# Altium schematic sheet Controle.SchDoc
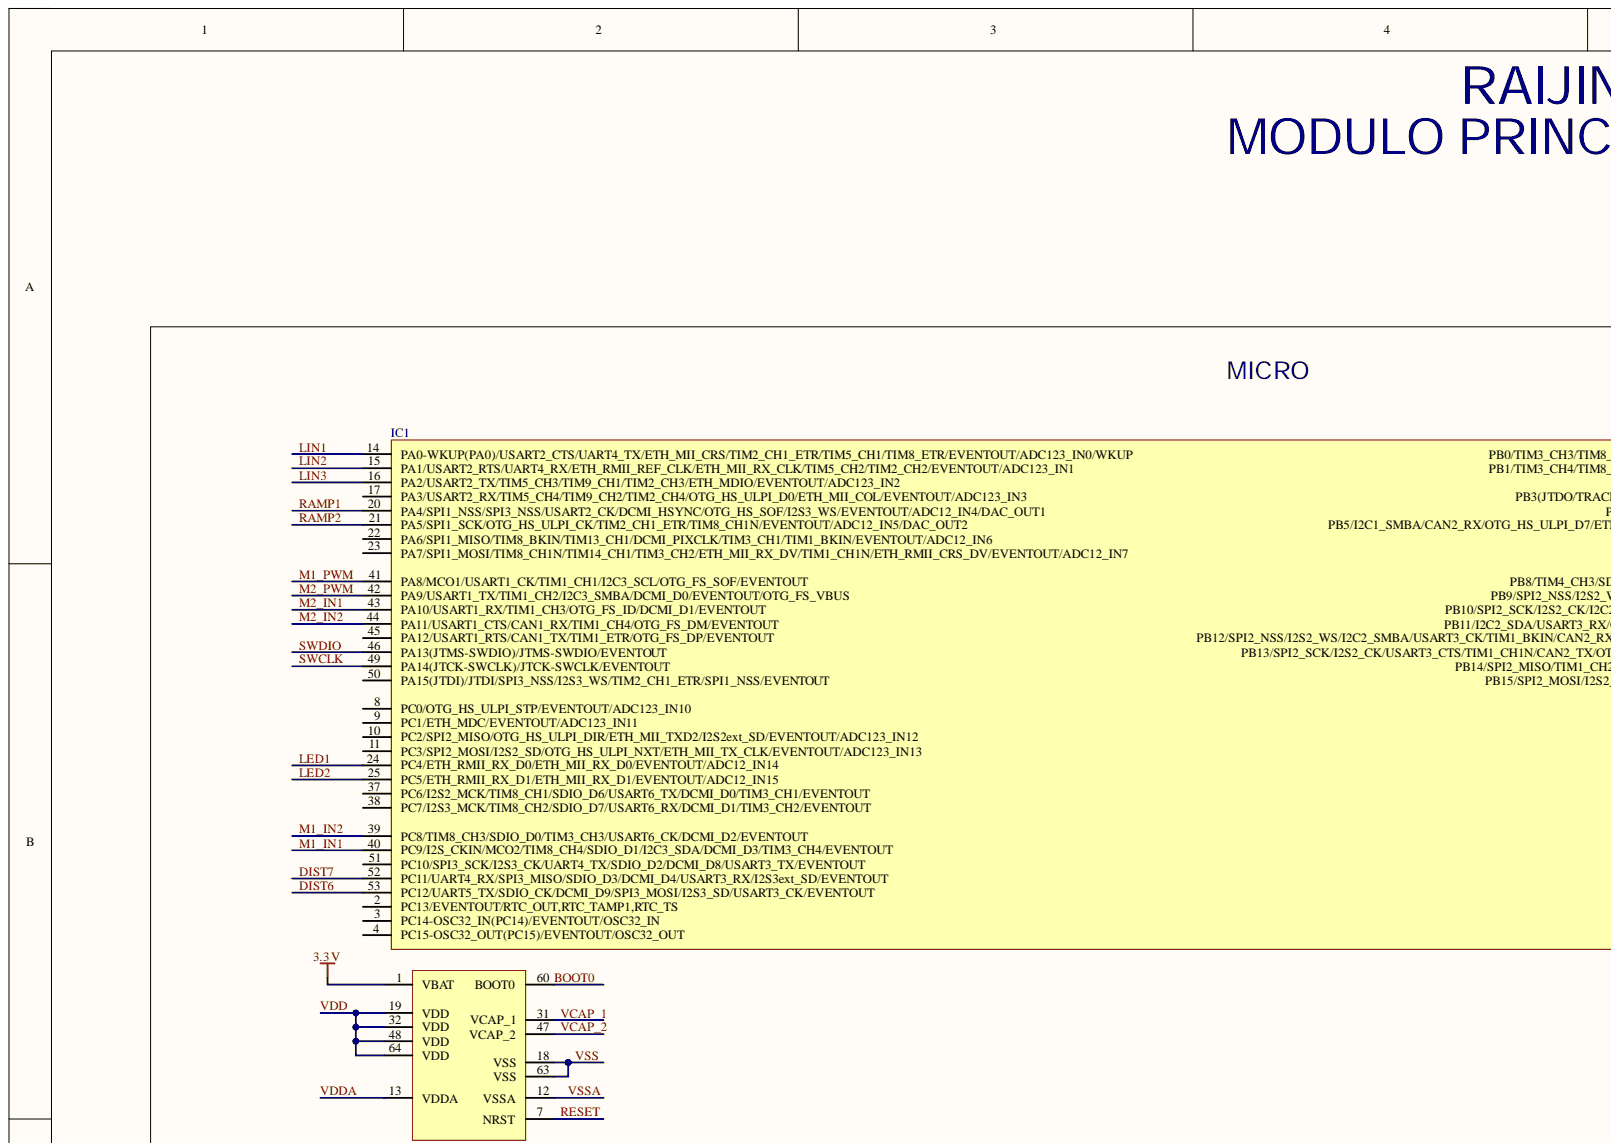
[diagram p0: Controle.SchDoc, top left]
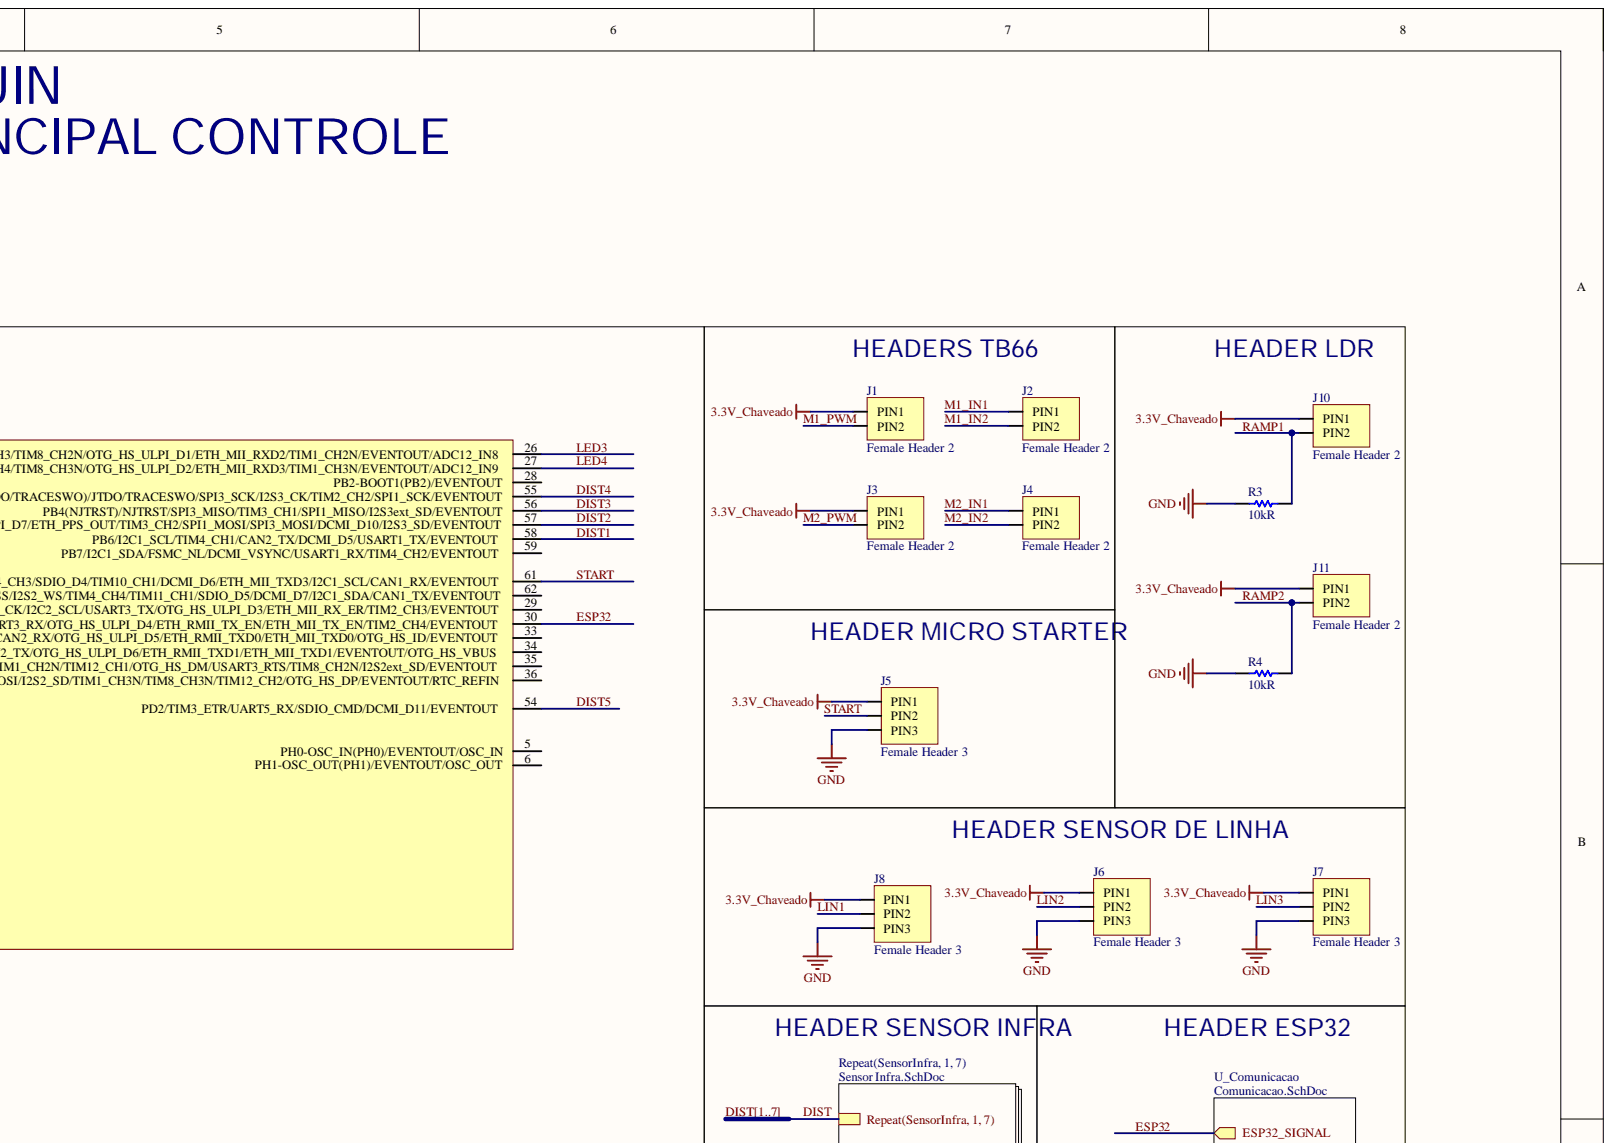
[diagram p1: Controle.SchDoc, top right]
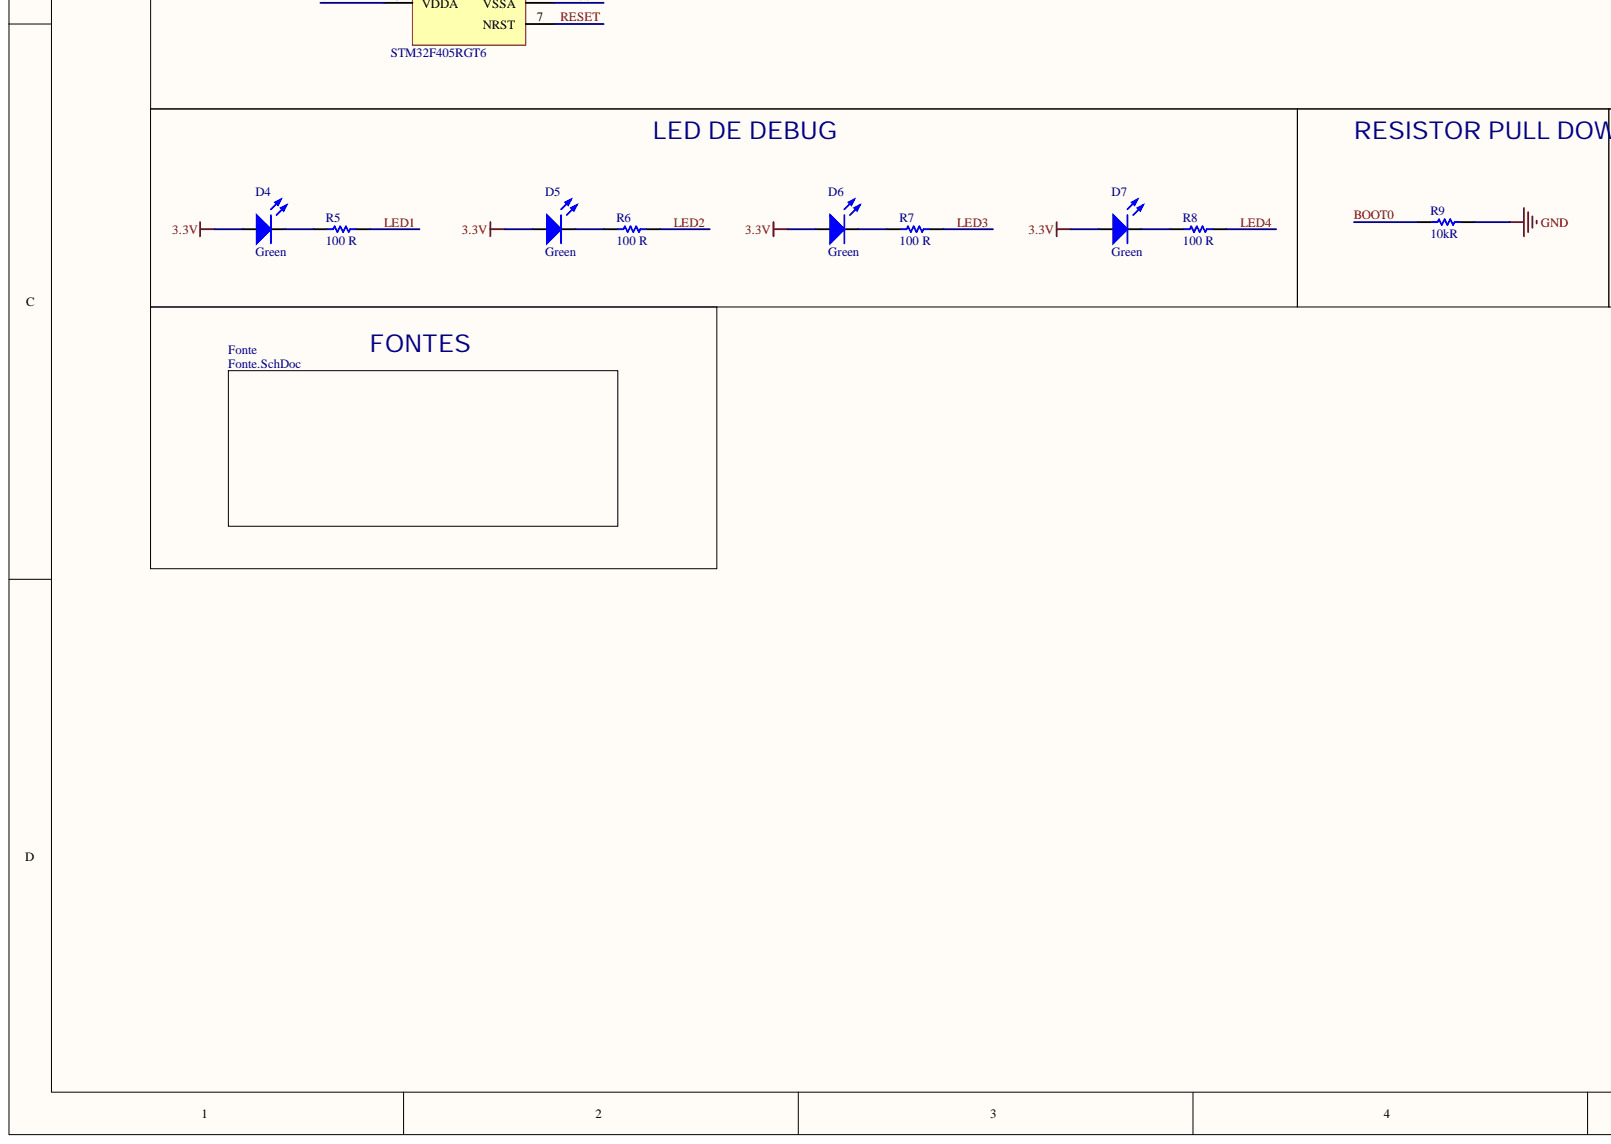
[diagram p2: Controle.SchDoc, bottom left]
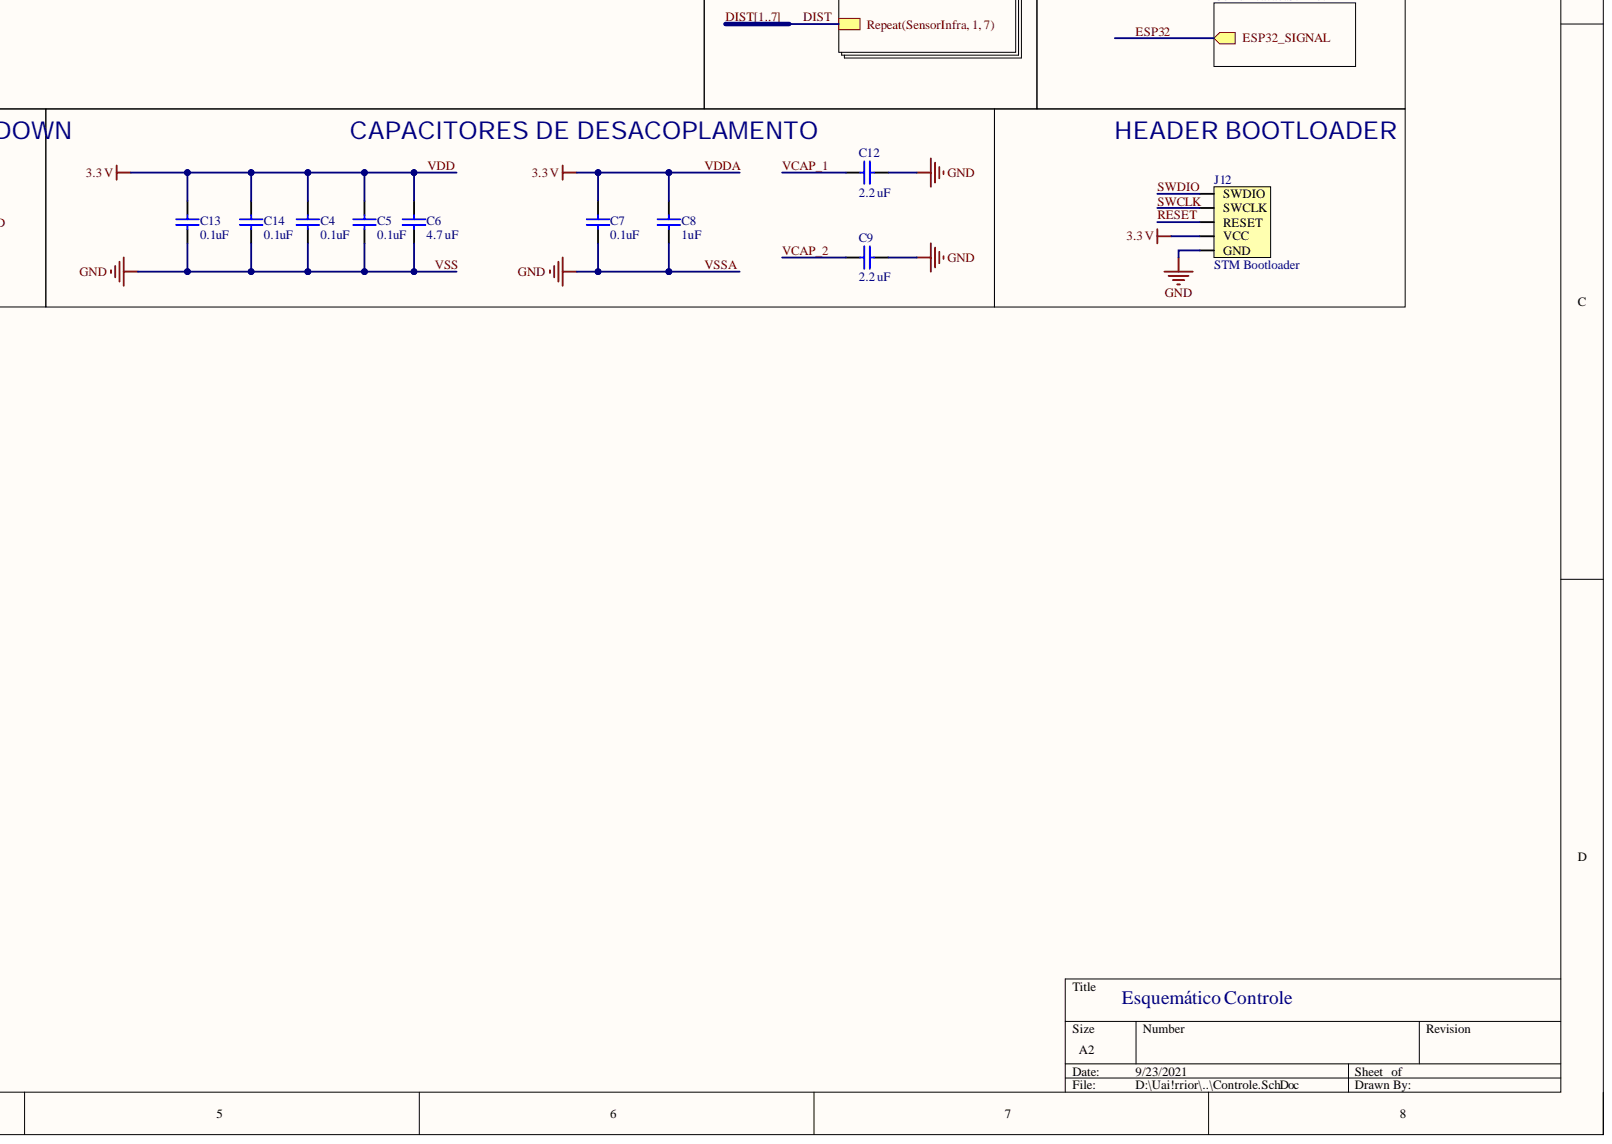
[diagram p3: Controle.SchDoc, bottom right]

## Parts
C4  0.1uF  [CAPC1005X55N]
C5  0.1uF  [CAPC1005X55N]
C6  4.7 uF  [C0603]
C7  0.1uF  [CAPC1005X55N]
C8  1uF  [C0805]
C9  2.2 uF  [C0402]
C12  2.2 uF  [C0402]
C13  0.1uF  [CAPC1005X55N]
C14  0.1uF  [CAPC1005X55N]
D4  Green  [LED_0603]
D5  Green  [LED_0603]
D6  Green  [LED_0603]
D7  Green  [LED_0603]
IC1  STM32F405RGT6  [LQFP-64]
J1  Female Header 2  [Female Header 2]
J2  Female Header 2  [Female Header 2]
J3  Female Header 2  [Female Header 2]
J4  Female Header 2  [Female Header 2]
J5  Female Header 3  Library Ref: Header  [FEMALE HEADER 3]
J6  Female Header 3  Library Ref: Header  [FEMALE HEADER 3]
J7  Female Header 3  Library Ref: Header  [FEMALE HEADER 3]
J8  Female Header 3  Library Ref: Header  [FEMALE HEADER 3]
J10  Female Header 2  [Female Header 2]
J11  Female Header 2  [Female Header 2]
J12  STM Bootloader  [STM BOOTLOADER]
R3  10kR  [RESISTOR_0402]
R4  10kR  [RESISTOR_0402]
R5  100 R  [RESISTOR_0603]
R6  100 R  [RESISTOR_0603]
R7  100 R  [RESISTOR_0603]
R8  100 R  [RESISTOR_0603]
R9  10kR  [RESISTOR_0402]

## Nets
3.3V: D4.A D5.A D6.A D7.A
3.3V_Chaveado: J1.PIN1 J3.PIN1 J5.PIN1 J6.PIN1 J7.PIN1 J8.PIN1 J10.PIN1 J11.PIN1
BOOT0: IC1.60(BOOT0) R9.1
DIST1: IC1.58(PB6/I2C1_SCL/TIM4_CH1/CAN2_TX/DCMI_D5/USART1_TX/EVENTOUT)  [also labelled DIST]
DIST2: IC1.57(PB5/I2C1_SMBA/CAN2_RX/OTG_HS_ULPI_D7/ETH_PPS_OUT/TIM3_CH2/SPI1_MOSI/SPI3_MOSI/DCMI_D10/I2S3_SD/EVENTOUT)  [also labelled DIST]
DIST3: IC1.56(PB4(NJTRST)/NJTRST/SPI3_MISO/TIM3_CH1/SPI1_MISO/I2S3ext_SD/EVENTOUT)  [also labelled DIST]
DIST4: IC1.55(PB3(JTDO/TRACESWO)/JTDO/TRACESWO/SPI3_SCK/I2S3_CK/TIM2_CH2/SPI1_SCK/EVENTOUT)  [also labelled DIST]
DIST5: IC1.54(PD2/TIM3_ETR/UART5_RX/SDIO_CMD/DCMI_D11/EVENTOUT)  [also labelled DIST]
DIST6: IC1.53(PC12/UART5_TX/SDIO_CK/DCMI_D9/SPI3_MOSI/I2S3_SD/USART3_CK/EVENTOUT)  [also labelled DIST]
DIST7: IC1.52(PC11/UART4_RX/SPI3_MISO/SDIO_D3/DCMI_D4/USART3_RX/I2S3ext_SD/EVENTOUT)  [also labelled DIST]
ESP32: IC1.30(PB11/I2C2_SDA/USART3_RX/OTG_HS_ULPI_D4/ETH_RMII_TX_EN/ETH_MII_TX_EN/TIM2_CH4/EVENTOUT)
LED1: IC1.24(PC4/ETH_RMII_RX_D0/ETH_MII_RX_D0/EVENTOUT/ADC12_IN14) R5.2
LED2: IC1.25(PC5/ETH_RMII_RX_D1/ETH_MII_RX_D1/EVENTOUT/ADC12_IN15) R6.2
LED3: IC1.26(PB0/TIM3_CH3/TIM8_CH2N/OTG_HS_ULPI_D1/ETH_MII_RXD2/TIM1_CH2N/EVENTOUT/ADC12_IN8) R7.2
LED4: IC1.27(PB1/TIM3_CH4/TIM8_CH3N/OTG_HS_ULPI_D2/ETH_MII_RXD3/TIM1_CH3N/EVENTOUT/ADC12_IN9) R8.2
LIN1: IC1.14(PA0-WKUP(PA0)/USART2_CTS/UART4_TX/ETH_MII_CRS/TIM2_CH1_ETR/TIM5_CH1/TIM8_ETR/EVENTOUT/ADC123_IN0/WKUP) J8.PIN2
LIN2: IC1.15(PA1/USART2_RTS/UART4_RX/ETH_RMII_REF_CLK/ETH_MII_RX_CLK/TIM5_CH2/TIM2_CH2/EVENTOUT/ADC123_IN1) J6.PIN2
LIN3: IC1.16(PA2/USART2_TX/TIM5_CH3/TIM9_CH1/TIM2_CH3/ETH_MDIO/EVENTOUT/ADC123_IN2) J7.PIN2
M1_IN1: IC1.40(PC9/I2S_CKIN/MCO2/TIM8_CH4/SDIO_D1/I2C3_SDA/DCMI_D3/TIM3_CH4/EVENTOUT) J2.PIN1
M1_IN2: IC1.39(PC8/TIM8_CH3/SDIO_D0/TIM3_CH3/USART6_CK/DCMI_D2/EVENTOUT) J2.PIN2
M1_PWM: IC1.41(PA8/MCO1/USART1_CK/TIM1_CH1/I2C3_SCL/OTG_FS_SOF/EVENTOUT) J1.PIN2
M2_IN1: IC1.43(PA10/USART1_RX/TIM1_CH3/OTG_FS_ID/DCMI_D1/EVENTOUT) J4.PIN1
M2_IN2: IC1.44(PA11/USART1_CTS/CAN1_RX/TIM1_CH4/OTG_FS_DM/EVENTOUT) J4.PIN2
M2_PWM: IC1.42(PA9/USART1_TX/TIM1_CH2/I2C3_SMBA/DCMI_D0/EVENTOUT/OTG_FS_VBUS) J3.PIN2
RAMP1: IC1.20(PA4/SPI1_NSS/SPI3_NSS/USART2_CK/DCMI_HSYNC/OTG_HS_SOF/I2S3_WS/EVENTOUT/ADC12_IN4/DAC_OUT1) J10.PIN2 R3.2
RAMP2: IC1.21(PA5/SPI1_SCK/OTG_HS_ULPI_CK/TIM2_CH1_ETR/TIM8_CH1N/EVENTOUT/ADC12_IN5/DAC_OUT2) J11.PIN2 R4.2
RESET: IC1.7 J12.RESET
START: IC1.61(PB8/TIM4_CH3/SDIO_D4/TIM10_CH1/DCMI_D6/ETH_MII_TXD3/I2C1_SCL/CAN1_RX/EVENTOUT) J5.PIN2
SWCLK: IC1.49(PA14(JTCK-SWCLK)/JTCK-SWCLK/EVENTOUT) J12.CLK(SWCLK)
SWDIO: IC1.46(PA13(JTMS-SWDIO)/JTMS-SWDIO/EVENTOUT) J12.DIO(SWDIO)
VCAP_1: C12.2 IC1.31(VCAP_1)
VCAP_2: C9.2 IC1.47
VDD: C4.2 C5.2 C6.2 C7.2 C8.2 C13.2 C14.2 IC1.1(VBAT) IC1.13(VDDA) IC1.19(VDD) IC1.32(VDD) IC1.48(VDD) IC1.64(VDD) J12.VCC
VSS: C4.1 C5.1 C6.1 C7.1 C8.1 C9.1 C12.1 C13.1 C14.1 IC1.12 IC1.18(VSS) IC1.63 J5.PIN3 J6.PIN3 J7.PIN3 J8.PIN3 J12.GND R3.1 R4.1 R9.2
NetD4_C: D4.C R5.1
NetD5_C: D5.C R6.1
NetD6_C: D6.C R7.1
NetD7_C: D7.C R8.1
NetIC1_2: IC1.2(PC13/EVENTOUT/RTC_OUT,RTC_TAMP1,RTC_TS)
NetIC1_3: IC1.3(PC14-OSC32_IN(PC14)/EVENTOUT/OSC32_IN)
NetIC1_4: IC1.4(PC15-OSC32_OUT(PC15)/EVENTOUT/OSC32_OUT)
NetIC1_5: IC1.5(PH0-OSC_IN(PH0)/EVENTOUT/OSC_IN)
NetIC1_6: IC1.6(PH1-OSC_OUT(PH1)/EVENTOUT/OSC_OUT)
NetIC1_8: IC1.8(PC0/OTG_HS_ULPI_STP/EVENTOUT/ADC123_IN10)
NetIC1_9: IC1.9(PC1/ETH_MDC/EVENTOUT/ADC123_IN11)
NetIC1_10: IC1.10(PC2/SPI2_MISO/OTG_HS_ULPI_DIR/ETH_MII_TXD2/I2S2ext_SD/EVENTOUT/ADC123_IN12)
NetIC1_11: IC1.11(PC3/SPI2_MOSI/I2S2_SD/OTG_HS_ULPI_NXT/ETH_MII_TX_CLK/EVENTOUT/ADC123_IN13)
NetIC1_17: IC1.17(PA3/USART2_RX/TIM5_CH4/TIM9_CH2/TIM2_CH4/OTG_HS_ULPI_D0/ETH_MII_COL/EVENTOUT/ADC123_IN3)
NetIC1_22: IC1.22(PA6/SPI1_MISO/TIM8_BKIN/TIM13_CH1/DCMI_PIXCLK/TIM3_CH1/TIM1_BKIN/EVENTOUT/ADC12_IN6)
NetIC1_23: IC1.23(PA7/SPI1_MOSI/TIM8_CH1N/TIM14_CH1/TIM3_CH2/ETH_MII_RX_DV/TIM1_CH1N/ETH_RMII_CRS_DV/EVENTOUT/ADC12_IN7)
NetIC1_28: IC1.28(PB2-BOOT1(PB2)/EVENTOUT)
NetIC1_29: IC1.29(PB10/SPI2_SCK/I2S2_CK/I2C2_SCL/USART3_TX/OTG_HS_ULPI_D3/ETH_MII_RX_ER/TIM2_CH3/EVENTOUT)
NetIC1_33: IC1.33(PB12/SPI2_NSS/I2S2_WS/I2C2_SMBA/USART3_CK/TIM1_BKIN/CAN2_RX/OTG_HS_ULPI_D5/ETH_RMII_TXD0/ETH_MII_TXD0/OTG_HS_ID/EVENTOUT)
NetIC1_34: IC1.34(PB13/SPI2_SCK/I2S2_CK/USART3_CTS/TIM1_CH1N/CAN2_TX/OTG_HS_ULPI_D6/ETH_RMII_TXD1/ETH_MII_TXD1/EVENTOUT/OTG_HS_VBUS)
NetIC1_35: IC1.35(PB14/SPI2_MISO/TIM1_CH2N/TIM12_CH1/OTG_HS_DM/USART3_RTS/TIM8_CH2N/I2S2ext_SD/EVENTOUT)
NetIC1_36: IC1.36(PB15/SPI2_MOSI/I2S2_SD/TIM1_CH3N/TIM8_CH3N/TIM12_CH2/OTG_HS_DP/EVENTOUT/RTC_REFIN)
NetIC1_37: IC1.37(PC6/I2S2_MCK/TIM8_CH1/SDIO_D6/USART6_TX/DCMI_D0/TIM3_CH1/EVENTOUT)
NetIC1_38: IC1.38(PC7/I2S3_MCK/TIM8_CH2/SDIO_D7/USART6_RX/DCMI_D1/TIM3_CH2/EVENTOUT)
NetIC1_45: IC1.45(PA12/USART1_RTS/CAN1_TX/TIM1_ETR/OTG_FS_DP/EVENTOUT)
NetIC1_50: IC1.50(PA15(JTDI)/JTDI/SPI3_NSS/I2S3_WS/TIM2_CH1_ETR/SPI1_NSS/EVENTOUT)
NetIC1_51: IC1.51(PC10/SPI3_SCK/I2S3_CK/UART4_TX/SDIO_D2/DCMI_D8/USART3_TX/EVENTOUT)
NetIC1_59: IC1.59(PB7/I2C1_SDA/FSMC_NL/DCMI_VSYNC/USART1_RX/TIM4_CH2/EVENTOUT)
NetIC1_62: IC1.62(PB9/SPI2_NSS/I2S2_WS/TIM4_CH4/TIM11_CH1/SDIO_D5/DCMI_D7/I2C1_SDA/CAN1_TX/EVENTOUT)

## Library data of the parts
C4 C5 C7 C13 C14 = GRM155R61C104KA88D: CAP CER 0.1uF 16V X5R 0402; Manufacturer: Murata; Library Control - Checked Footprint: Yes; Library Control - Person that Checked Footprint: Guilherme; Tolerance: 10%; Category: Ceramic Capacitors; Component Kind: Standard; ComponentLink1Description: Datasheet; ComponentLink1URL: https://br.mouser.com/datasheet/2/281/1/GRM155R61C104KA88_01A-1983743.pdf; ComponentLink2Description: Mouser Link; ComponentLink2URL: https://br.mouser.com/ProductDetail/Murata-Electronics/GRM155R61C104KA88D?qs=%2Fha2pyFadugsr51Fgcs3VGJIvx6dQb2fpcJyrxDKYTBEoW5q5paGTQ%3D%3D; Dieletric: X5R; ESR: -; Library Control - Checked Schematic: Yes; Library Control - Last Update: 24/07/2021 11:05; Library Ref: Capacitor; Operating Temperature: -55°C ~ 85°C; Package / Case: 0402 (1005 Metric); Part Number: GRM155R61C104KA88D; Price: 1 = 0,10, 10=0,024, 100=0,011 (USD); Size / Dimension: 1,0 L x 0,5 W x 0,5 H; Supplier 1: Mouser; Supplier Part Number 1: 81-GRM155R61C104KA8D; Value: 0.1uF; Voltage - Rated: 16V; Library Control - Person that Checked Schematic: Guilherme; Library Control - Person that did the Last Update Footprint: Guilherme; Library Control - Person that did the Last Update Schematic: Guilherme; Library Name: Uai!Tecas.IntLib
C6 = GRM188R61C475KAAJD: CAP CER 4.7uF 16V X5R 0603; Manufacturer: MURATA ELECTRONICS; ESR: 100mΩ; Library Control - Checked Schematic: Guilherme; Library Control - Person that did the Last Update Footprint: Raquel; Library Control - Person that did the Last Update Schematic: Marcos; Tolerance: 10%; Voltage - Rated: 16 VDC; Category: Ceramic capacitor; Component Kind: Standard; ComponentLink1Description: Datasheet; ComponentLink1URL: https://br.mouser.com/datasheet/2/281/murata_03052018_GRM_Series_1-1310166.pdf; ComponentLink2Description: Mouser Link; ComponentLink2URL: https://br.mouser.com/ProductDetail/Murata-Electronics/GRM188R61C475KAAJD?qs=mJtAphxf33T5qOjL9fHG9Q%3D%3D; Dieletric: X5R; Library Control - Last Update: 27/06/2021 16:02; Library Ref: Capacitor; Operating Temperature: -55°C ~ 85ºC; Package / Case: 0603(1608 metric); Part Number: GRM188R61C475KAAJD; Price: 1=0,39, 10=0,27, 100=0,172, 1000=0,153 (USD); Size / Dimension: 1.6 mm L x 0.8 mm W x 0.8 mm H; Supplier 1: Mouser; Supplier Part Number 1: 81-GRM188R61C475KAJD; Value: 4.7 uF; Library Control - Person that Checked Schematic: Guilherme; Library Name: Uai!Tecas.IntLib
C8 = CC0805ZRY5V8BB105: CAP CER 1uF 25V Y5V 0805; Manufacturer: Yageo; ESR: 0.05; Library Control - Checked Footprint: Yes; Library Control - Person that Checked Footprint: Nikaum; Tolerance: -20%, +80%; Category: Ceramic Capacitor; Component Kind: Standard; ComponentLink1Description: Datasheet; ComponentLink1URL: https://br.mouser.com/datasheet/2/447/yago_s_a0004841839_1-2286631.pdf; ComponentLink2Description: Mouser Link; ComponentLink2URL: https://br.mouser.com/ProductDetail/Yageo/CC0805ZRY5V8BB105?qs=%2Fha2pyFaduhNpscxq1sti%2FWGfef5%252B7abNQFh0pSFqzoFpwPoZWE8UM%252BupYNGXIAb; Dieletric: Y5V; Library Control - Checked Schematic: Yes; Library Control - Last Update: 27/06/2021 23:54; Library Ref: Capacitor; Operating Temperature: -30 C ~ 85 C; Package / Case: 0805 (2012 Metric); Part Number: CC0805ZRY5V8BB105; Price: 1=0.21, 10=0.151, 100=0.071 (USD); Size / Dimension: 2,00 L x 1,25 W x 0,85 H; Supplier 1: Mouser; Supplier Part Number 1: 603-CC805KRX7R9BB331; Value: 1uF; Voltage - Rated: 25V; Library Control - Person that Checked Schematic: Nikaum; Library Control - Person that did the Last Update Footprint: NIkaum; Library Control - Person that did the Last Update Schematic: Nikaum; Library Name: Uai!Tecas.IntLib
C9 C12 = C1005X5R1C225M050BC: CAP CER 2.2UF 16V X5R 0402; Manufacturer: TDK; Library Control - Checked Footprint: Yes; Library Control - Person that Checked Footprint: Nikaum; Tolerance: 20%; Voltage - Rated: 16 VDC; Category: Ceramic Capacitor; Component Kind: Standard; ComponentLink1Description: Datasheet; ComponentLink1URL: https://product.tdk.com/system/files/dam/doc/product/capacitor/ceramic/mlcc/catalog/mlcc_commercial_general_en.pdf; ComponentLink2Description: Mouser Link; ComponentLink2URL: https://br.mouser.com/ProductDetail/TDK/C1005X5R1C225M050BC?qs=%2Fha2pyFaduhU5KeTuzJ6cgu5msb4qv%252BHR3wvbG6WdO9o%252B44FZFkWvfar1ahjHCOq; ComponentLink3Description: ESR; ComponentLink3URL: https://product.tdk.com/en/search/capacitor/ceramic/mlcc/info?part_no=C1005X5R1C225M050BC; Dieletric: X5R; Library Control - Checked Schematic: Yes; Library Control - Last Update: 28/06/2021 00:25; Library Ref: Capacitor; Operating Temperature: -55 C ~ 85 C; Package / Case: 0402 (1005 Metric); Part Number: C1005X5R1C225M050BC; Price: 1= 0,35, 10= 0,24, 100= 0,137 (USD); Size / Dimension: 1,00 L x 0,50 W x 0,50 H; Supplier 1: Mouser; Supplier Part Number 1: 810-C1005X5R1C225M; Value: 2.2 uF; Library Control - Person that Checked Schematic: Nikaum; Library Control - Person that did the Last Update Footprint: Nikaum; Library Control - Person that did the Last Update Schematic: Nikaum; Library Name: Uai!Tecas.IntLib
D4-D7 = 150060VS75000: LED 0.072W GREEN 40MCD 0603; Manufacturer: Wurth Elektronik; Current - Forward (If): 20 mA; Library Control - Last Project that Used: Diodo/Led; Library Control - Person that Checked Footprint: Stéfany (Ster); Library Control - Person that did the Last Update Schematic: Marcos; Max Current Rating: 100mA; Max Power Dissipation: 72mW; Reverse Voltage: 5V; Viewing Angle: 140°; Voltage - Forward (Vf): 2 V; Wavelength: 570nm; Category: Standard LEDs - SMD; Color: Green; Component Kind: Standard; ComponentLink1Description: Mouser Link; ComponentLink1URL: https://br.mouser.com/ProductDetail/Wurth-Elektronik/150060VS75000?qs=%2Fha2pyFaduhuT%2FKGSijZP0vTyJZ9U993KfsBQSxqATYhY9jnc2c09tXoMoJ3wn4L; ComponentLink2Description: Datasheet Link; ComponentLink2URL: https://br.mouser.com/datasheet/2/445/150060VS75000-1714627.pdf; Library Control - Checked Footprint: 25/07/2021; Library Control - Checked Schematic: 25/07/2021; Library Control - Last Update: 09/09/2021 16:12; Library Ref: LED; Luminous Intensity: 40 mcd; Operating Temperature: -40~85 °C; Package / Case: 0603; Part Number: 150060VS75000; Price: 1 = 0.14, 50 = 0.133, 100 = 0.114 (USD); Supplier 1: Mouser; Supplier Part Number 1: 710-150060VS75000; Library Control - Person that Checked Schematic: Stéfany (Ster); Library Control - Person that did the Last Update Footprint: Stéfany (Ster); Library Name: Uai!Tecas.IntLib
IC1 = STM32F405RGT6: IC MCU 32-BIT 192kB FLASH ARM Cortex-M4 168MHz  LQFP-64; Manufacturer: STM; Core Processor: ARM Cortex M0+; Library Control - Person that did the Last Update Footprint: Marcos; Number of ADC Channels: 16; Number of I/Os: 51; Number of Timers/Counters: 17; Oscillator Type: Internal; Peripherals: CAN, I2C, SDIO, I2S / SPI, UART / USART, USB; Program Memory Size: 1024kB; Voltage - Supply (Vcc/Vdd): 1.8 V to 3.6 V; Category: ARM Microcontrollers - MCU; Component Kind: Standard; ComponentLink1Description: Mouser Link; ComponentLink1URL: https://www.mouser.com/ProductDetail/STMicroelectronics/STM32G030J6M6?qs=uwxL4vQweFMe%2Fiu4dY6izA%3D%3D; ComponentLink2Description: Datasheet Link; ComponentLink2URL: https://www.mouser.com/datasheet/2/389/dm00613878-1799578.pdf; Connectivity: -; Library Ref: Microcrontrollers; Number of Ethernet Channels: -; Number of I2C Channels: -; Number of SPI Channels: -; Number of UART/USART Channels: -; Operating Temperature: -40°C to 85°C; Package/Case: LQFP-64; Part Number: STM32F405RGT6; Price: 1 = 12,95, 10 = 11,91,  100 = 11,42, 500 = 8,95 (USD); Program Memory Type: Flash; RAM Size: 192k B; Series: STM32F405RG; Speed: 168MHz; Supplier 1: Mouser; Supplier Part Number 1: 511-STM32F405RGT6; Library Control - Person that did the Last Update Schematic: Marcos; Library Name: Uai!Tecas.IntLib
J1-J4 J10 J11 = Female Header 2: System: Connector; Component Kind: Standard; Description: Female Header 2; Library Name: Header.SchLib
J5-J8 = Female Header 3: Number of Contacts: 3; System: Connector; Component Kind: Standard; Description: Female Header 3; Library Name: Header.SchLib
J12 = Barramento de Gravação STM: BARRAMENTO DE GRAVAÇÃO; Number of Contacts: 5; Component Kind: Standard; Library Ref: Header; Library Name: Uai!Tecas.IntLib
R3 R4 R9 = RC0402JR-0710KL: RES SMD 10K OHM 5% 1/16W 0402; Manufacturer: Yageo; Library Control - Checked Footprint: Yes; Library Control - Person that Checked Footprint: Nikaum; Library Control - Person that did the Last Update Footprint: Dalarme; Power Rating: 62.5 mW (1/16 W); Temperature Coefficient: 100 PPM / C; Voltage Rating: 50 V; Category: Thick Film Resistors - SMD; Component Kind: Standard; ComponentLink1Description: Mouser Link; ComponentLink1URL: https://br.mouser.com/ProductDetail/Yageo/RC0402JR-0710KL?qs=%2Fha2pyFadugksLQP8sr8wSFqaMq1e9zbbX4dfYXawN%252BqoBT8jIbVcw%3D%3D; ComponentLink2Description: Datasheet Link; ComponentLink2URL: https://br.mouser.com/datasheet/2/447/PYu_RC_Group_51_RoHS_L_10-1664068.pdf; Library Control - Checked Schematic: Yes; Library Control - Last Update: 27/06/2021 20:59; Library Ref: Resistor; Operating Temperature: -55 C ~ 155 C; Package / Case: 0402 (1005 Metric); Part Number: RC0402JR-0710KL; Price: 1=0,10, 10=0,01, 100=0,004 1000=0,003 (USD); Resistor Type: Fixo; Size / Dimension: 1 mm L x  0.5 mm W x  0.35 mm H; Supplier 1: Mouser; Supplier Part Number 1: 603-RC0402JR-0710KL; Tolerance: 5 %; Value: 10kR; Library Control - Person that Checked Schematic: Nikaum; Library Control - Person that did the Last Update Schematic: Nikaum; Library Name: Uai!Tecas.IntLib
R5-R8 = RC0603FR-10100RL: RES SMD 100 OHM 1% 1/10W 0603; Manufacturer: Yageo; Library Control - Checked Footprint: Yes; Library Control - Person that Checked Footprint: Nikaum; Library Control - Person that did the Last Update Footprint: Nicolas; Power Rating: 100mW (1/10W); Temperature Coefficient: 100 PPM / C; Voltage Rating: 75 V; Category: Thick Film Resistors - SMD; Component Kind: Standard; ComponentLink1Description: Mouser Link; ComponentLink1URL: https://br.mouser.com/ProductDetail/Yageo/RC0603FR-10100RL/?qs=EiqXWrxQq62RG9T9SdQg0g==; ComponentLink2Description: Datasheet Link; ComponentLink2URL: https://br.mouser.com/datasheet/2/447/Yageo_03_18_2021_PYu_RC_Group_51_RoHS_L_11-2199992.pdf; Library Control - Checked Schematic: Yes; Library Control - Last Update: 27/06/2021 21:11; Library Ref: Resistor; Operating Temperature: - 55 C~155 C; Package / Case: 0603 (1608 metric); Part Number: RC0603FR-10100RL; Price: 1 = 0,10, 10 = 0,018, 100 = 0,006 (USD); Resistor Type: Fixo; Size / Dimension: 1,60 L x 0,80 W x 0,45 H; Supplier 1: Mouser; Supplier Part Number 1: 603-RC0603FR-10100RL; Tolerance: 1 %; Value: 100 R; Library Control - Person that Checked Schematic: Nikaum; Library Control - Person that did the Last Update Schematic: Nikaum; Library Name: Uai!Tecas.IntLib
